# Altium schematic sheet PiTopUSBHub.SchDoc
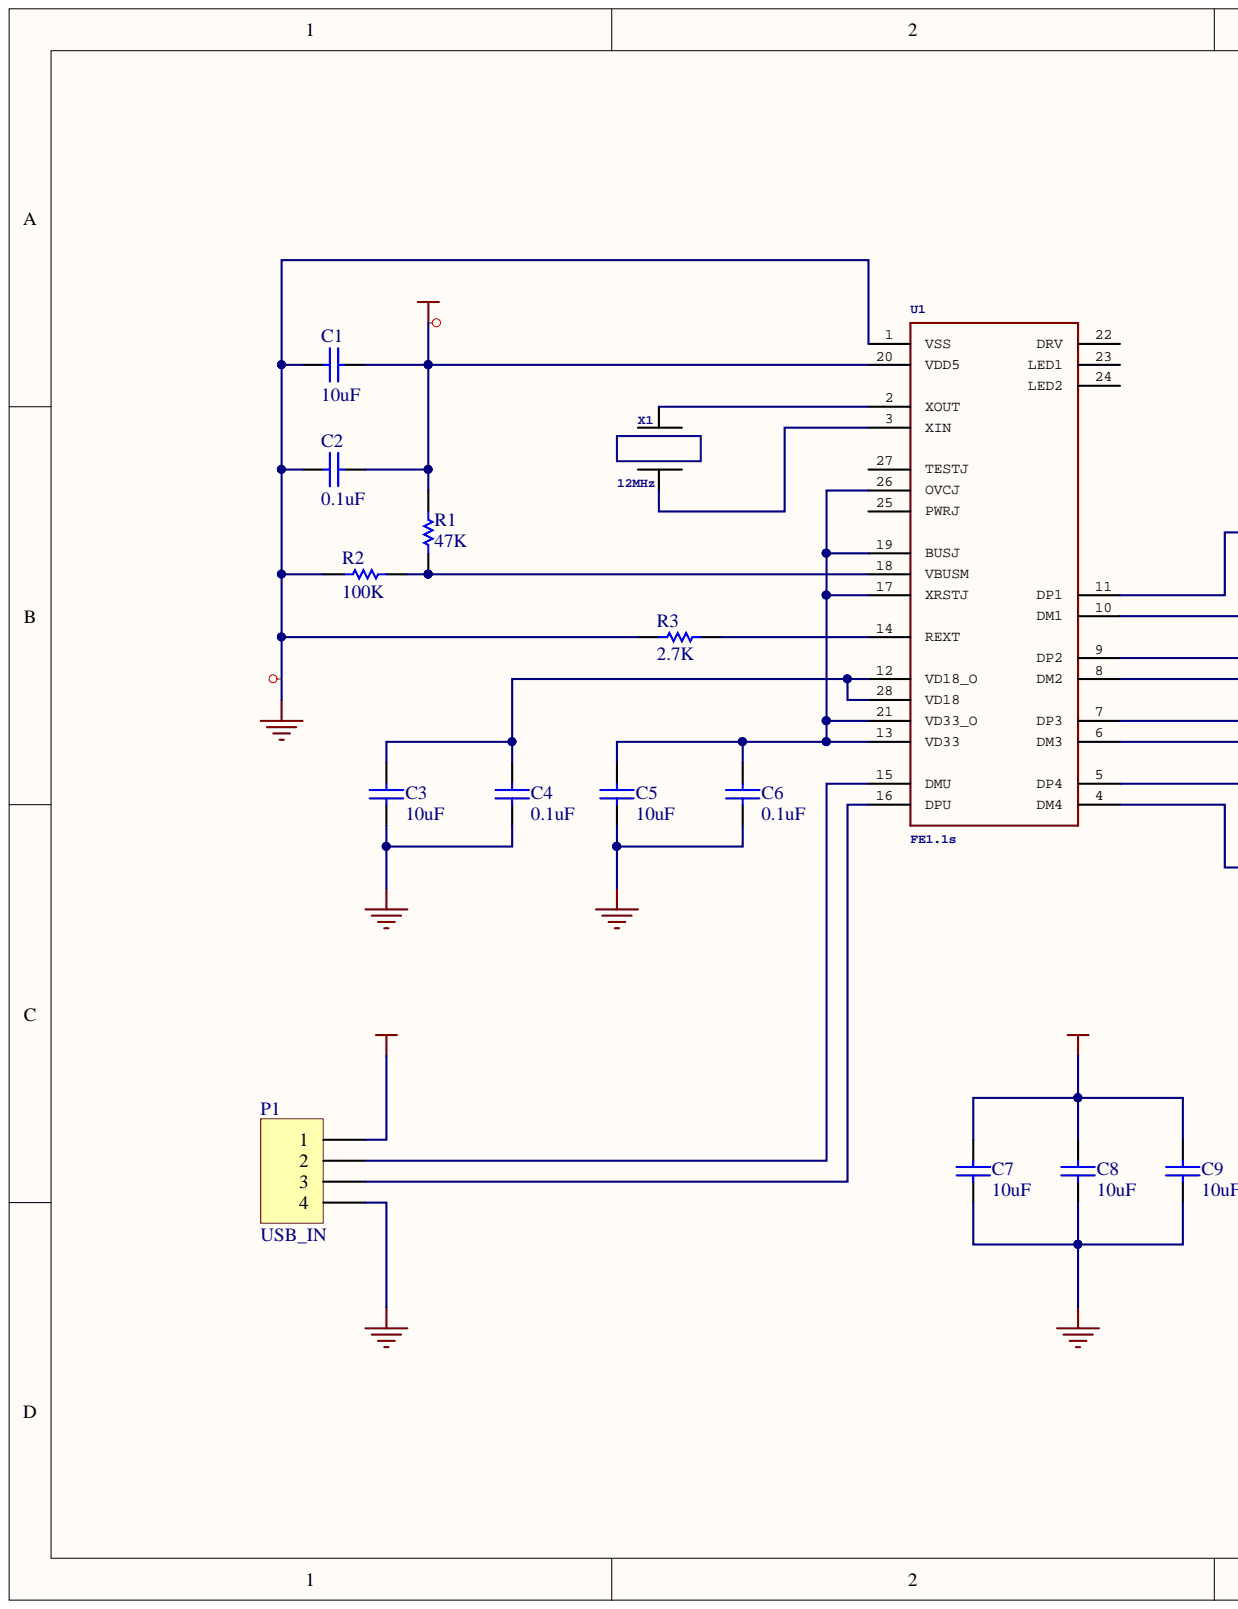
[diagram p0: PiTopUSBHub.SchDoc, left]
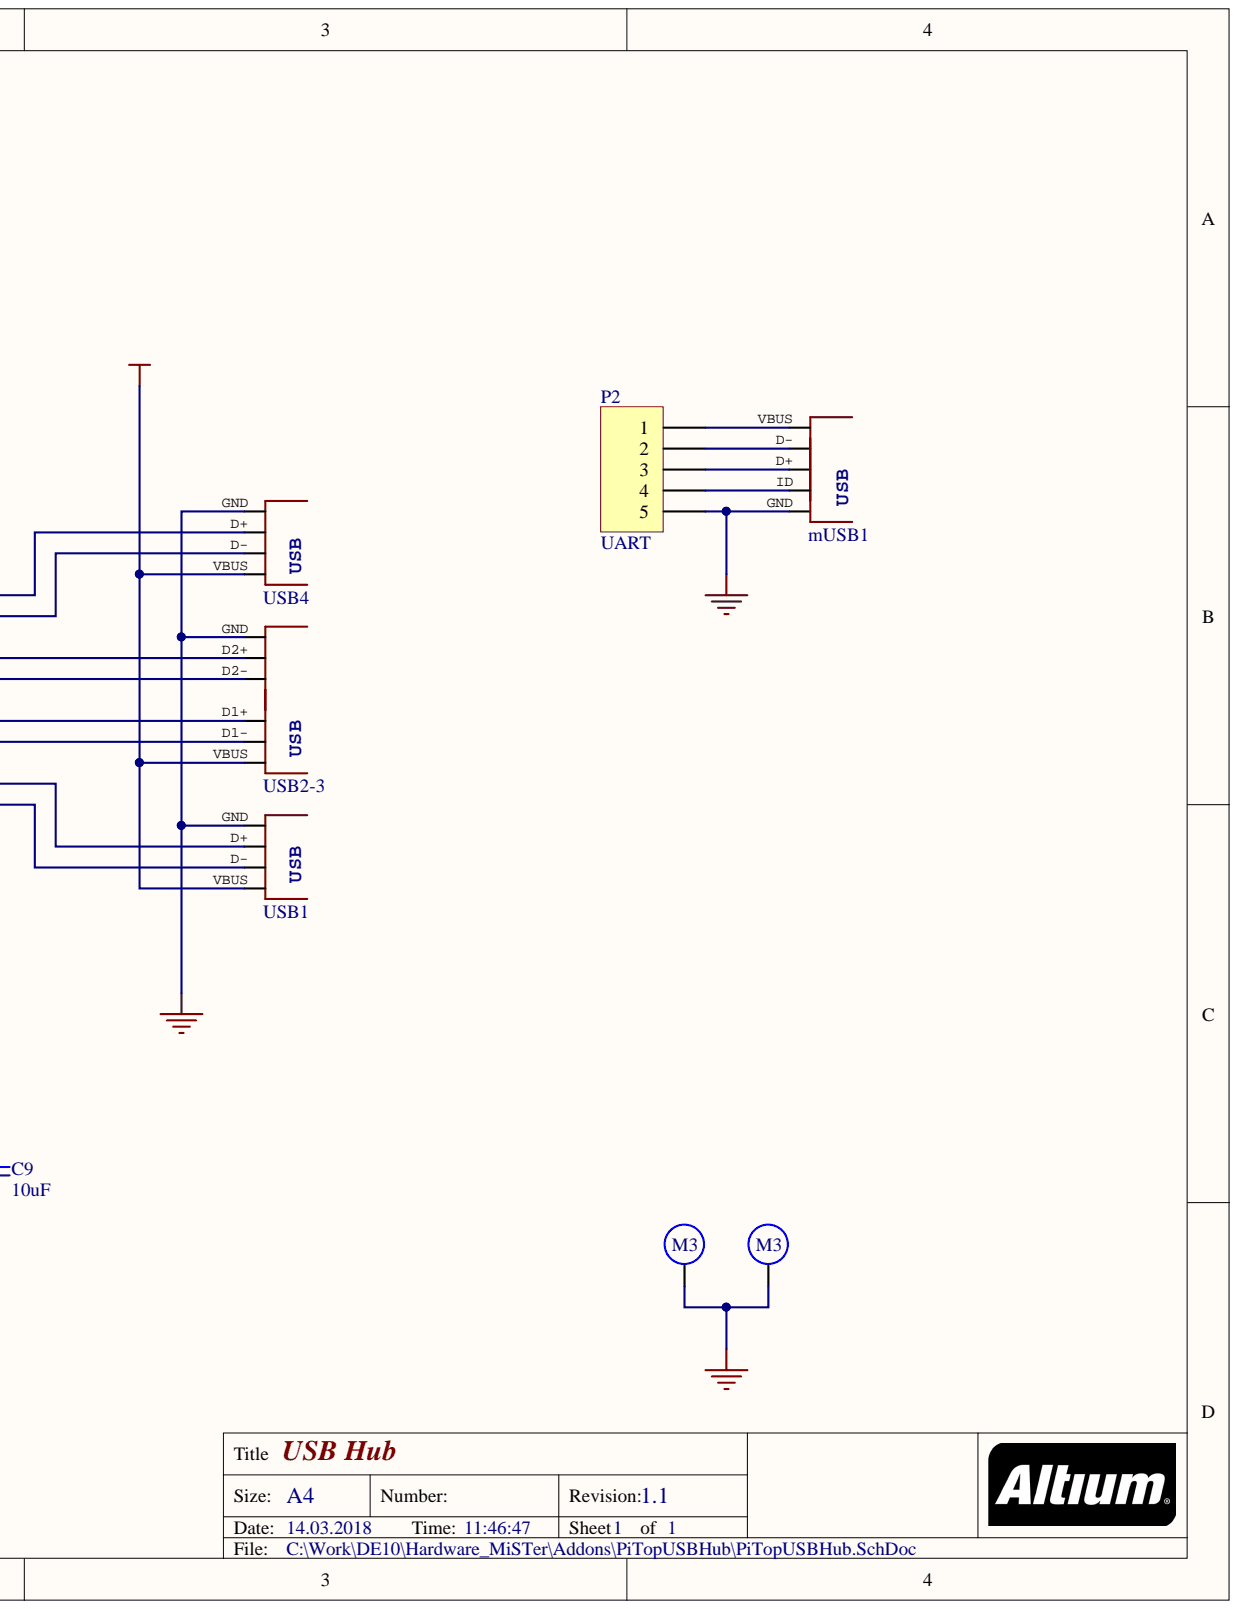
[diagram p1: PiTopUSBHub.SchDoc, right]

## Parts
C1  value 10uF  [C0805]  (hidden comment: C0805)
C2  value 0.1uF  [C0805]  (hidden comment: C0805)
C3  value 10uF  [C0805]  (hidden comment: C0805)
C4  value 0.1uF  [C0805]  (hidden comment: C0805)
C5  value 10uF  [C0805]  (hidden comment: C0805)
C6  value 0.1uF  [C0805]  (hidden comment: C0805)
C7  value 10uF  [C0805]  (hidden comment: C0805)
C8  value 10uF  [C0805]  (hidden comment: C0805)
C9  value 10uF  [C0805]  (hidden comment: C0805)
H1  M3  [M3]
H2  M3  [M3]
mUSB1  miniUSB-PTH  [miniUSB-F-PTH]  (value not drawn)
P1  USB_IN  [HDR1X4]
P2  UART  [HDR1X5]
R1  47K  [R0805]
R2  100K  [R0805]
R3  2.7K  [R0805]
U1  FE1.1S  [FE1.1S_SSOP-28]
USB1  USBA-PTH  [USB-A-F-PTH]  (value not drawn)
USB2-3  USBA2x-PTH  [USB-A-2x-F-PTH]  (value not drawn)
USB4  USBA-PTH  [USB-A-F-PTH]  (value not drawn)
X1  12MHz  [XTAL_G5032]

## Nets
GND: C1.2 C2.2 C3.2 C4.2 C5.2 C6.2 C7.2 C8.2 C9.2 H1.1 H2.1 mUSB1.GND P1.4 P2.5 R2.2 R3.1 U1.1(VSS) USB1.GND USB2-3.GND USB4.GND
VCC: C1.1 C2.1 C7.1 C8.1 C9.1 P1.1 R1.1 U1.20(VDD5) USB1.VBUS USB2-3.VBUS USB4.VBUS
NetC3_1: C3.1 C4.1 U1.12(VD18_O) U1.28(VD18)
NetC5_1: C5.1 C6.1 U1.13(VD33) U1.17(XRSTJ) U1.19(BUSJ) U1.21(VD33_O) U1.26(OVCJ)
NetmUSB1_D+: mUSB1.D+ P2.3
NetmUSB1_D-: mUSB1.D- P2.2
NetmUSB1_ID: mUSB1.ID P2.4
NetmUSB1_VBUS: mUSB1.VBUS P2.1
NetP1_2: P1.2 U1.15(DMU)
NetP1_3: P1.3 U1.16(DPU)
NetR1_2: R1.2 R2.1 U1.18(VBUSM)
NetR3_2: R3.2 U1.14(REXT)
NetU1_2: U1.2(XOUT) X1.2
NetU1_3: U1.3(XIN) X1.1
NetU1_4: U1.4(DM4) USB1.D-
NetU1_5: U1.5(DP4) USB1.D+
NetU1_6: U1.6(DM3) USB2-3.D1-
NetU1_7: U1.7(DP3) USB2-3.D1+
NetU1_8: U1.8(DM2) USB2-3.D2-
NetU1_9: U1.9(DP2) USB2-3.D2+
NetU1_10: U1.10(DM1) USB4.D-
NetU1_11: U1.11(DP1) USB4.D+

Not connected: U1 22(DRV) 23(LED1) 24(LED2) 25(PWRJ) 27(TESTJ)

## Library data of the parts
C1-C9 = C0805: Ceramic Chip Capacitor - Standard; Note: Footprint corresponds to dimensions for bulk cassette packaging. This case size has tightened tolerances.; Code_IPC: 2012[0805]; Code_JEITA: 0805; Component Kind: Standard; ComponentLink1Description: Manufacturer Link; ComponentLink1URL: http://www.kemet.com/; ComponentLink2Description: Datasheet; ComponentLink2URL: http://www.kemet.com/kemet/web/homepage/kechome.nsf/vapubfilesname/F3102TA.pdf/$file/F3102TA.pdf; DatasheetDocument: Mar-2001; LatestRevisionDate: 19-Mar-2004; LatestRevisionNote: Silkscreen size reduced.; Library Name: KEMET Chip Capacitor.IntLib; PackageDocument: Mar-2001; PackageReference: 0805; Published: 17-Jul-2002; Signal Integrity: C0805; Simulation: CAP; Publisher: Altium Limited
mUSB1 = miniUSBA-PTH: Component Kind: Standard; Library Name: lib.SchLib; USB: USB
P1 = Header 4: Header, 4-Pin; Component Kind: Standard; LatestRevisionDate: 17-Jul-2002; LatestRevisionNote: Re-released for DXP Platform.; Library Name: Miscellaneous Connectors.IntLib; Signal Integrity: Connector; Publisher: Altium Limited
P2 = Header 5: Header, 5-Pin; Component Kind: Standard; LatestRevisionDate: 17-Jul-2002; LatestRevisionNote: Re-released for DXP Platform.; Library Name: Miscellaneous Connectors.IntLib; Signal Integrity: Connector; Publisher: Altium Limited
R1-R3 = ERJ6RS: Low Ohmic Value Thick Film Chip Resistor, 0.1 Ohm to 0.2 Ohm Range, 1%, 2% and 5% Tolerance, 0805 Size, 0.125 W; Code_IPC: 2012 [0805]; Component Kind: Standard; ComponentLink1Description: Manufacturer Link; ComponentLink1URL: http://www.panasonic.com/industrial/components/resistive_products.htm; ComponentLink2Description: Datasheet; ComponentLink2URL: http://www.panasonic.com/industrial/components/pdf/AOA0000CE3.pdf; LatestRevisionDate: 19-Mar-2004; LatestRevisionNote: Silkscreen size reduced.; Library Name: lib.PcbLib; PackageDocument: Mar-2003; PackageReference: 6-0805; Published: 17-Dec-2003; Signal Integrity: Res; Simulation: RESISTOR; Publisher: Altium Limited
X1 = CRYSTALHC49UP: PTH: ...   SMD: ...   Cylinder: CMR200T-32.768KDZBT; Component Kind: Standard; Library Name: lib.SchLib
H1 H2 = M3: Component Kind: Standard; Library Name: lib.SchLib
U1 = FE1.1S: Component Kind: Standard; FE1.1s: FE1.1s; Library Name: lib.SchLib
USB1 USB4 = USBA-PTH: Component Kind: Standard; Library Name: lib.SchLib; USB: USB
USB2-3 = USBA2x-PTH: Component Kind: Standard; Library Name: lib.SchLib; USB: USB
